# Altium schematic sheet 05_DC-DC_CONV.SchDoc(05_DC-DC_CONV)
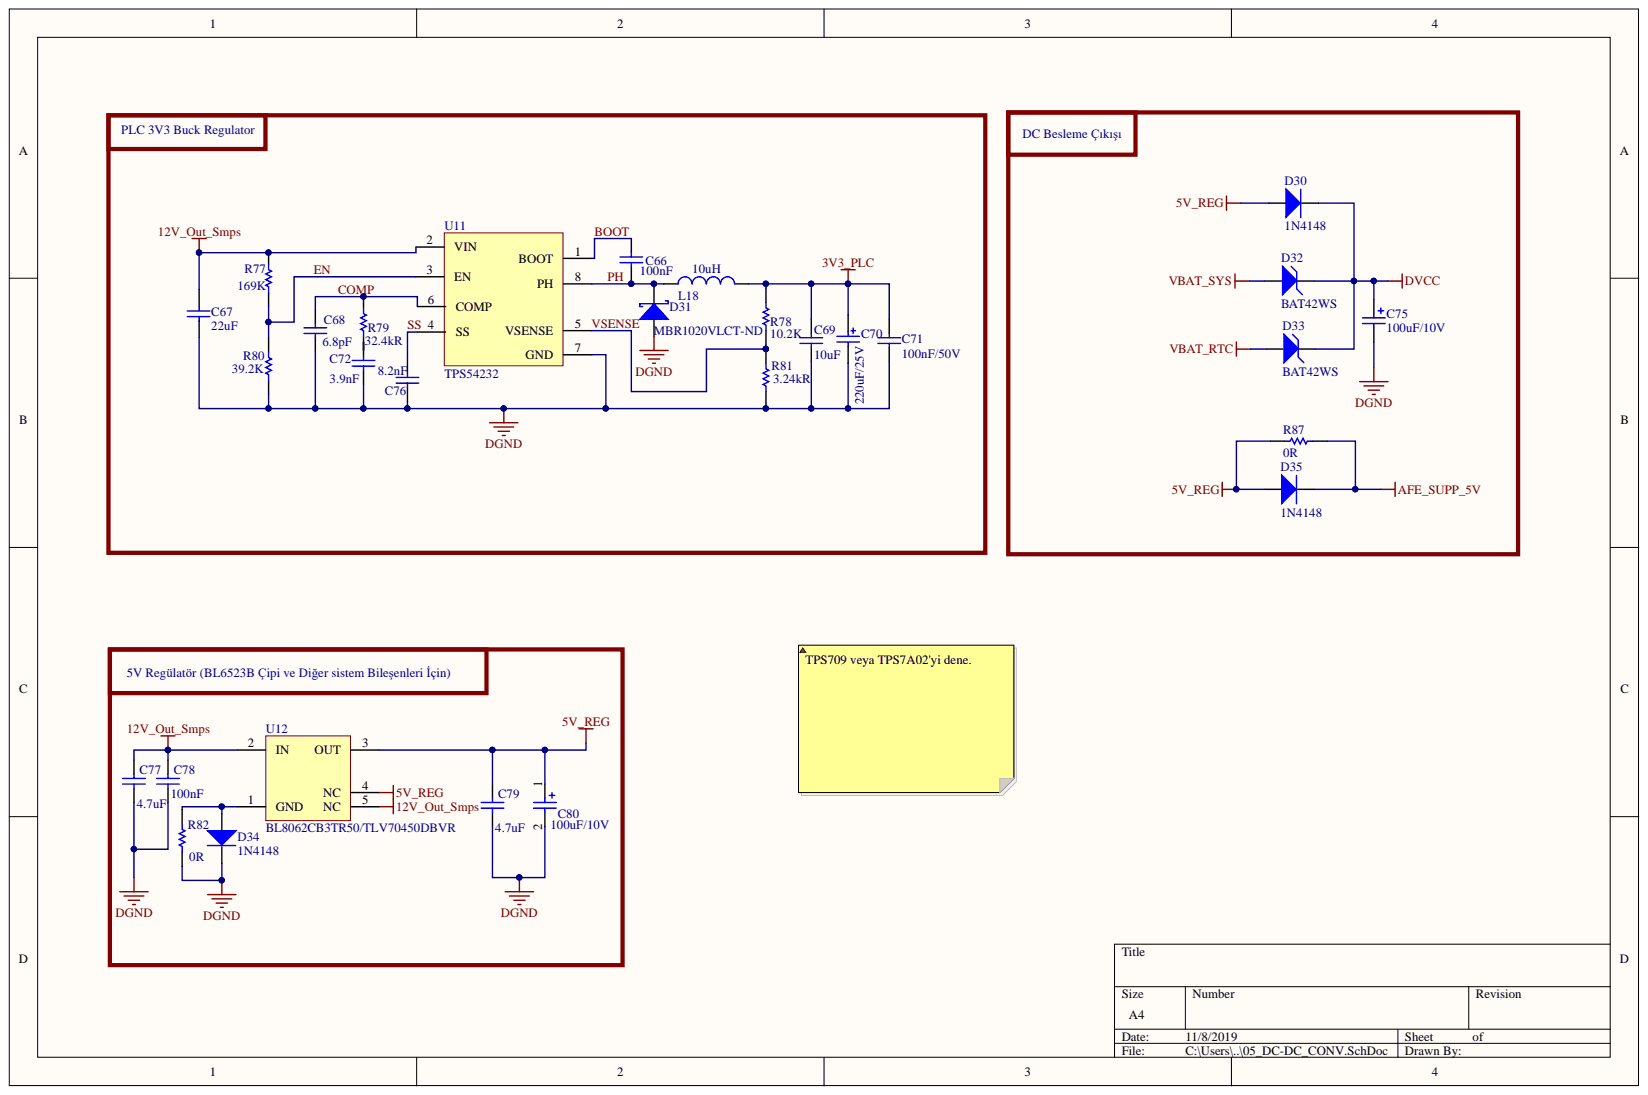
[diagram p0: 05_DC-DC_CONV.SchDoc]

## Parts
C66  Capacitance: 100nF  [CAP_0402]  (hidden comment: CAP_SMD)
C67  Capacitance: 22uF  [RES_1210_V1]  (hidden comment: GRM32ER61E226KE15L)
C68  Capacitance: 6.8pF  (hidden comment: CAP_SMD)
C69  Capacitance: 10uF  [CAP_0805_V1]  (hidden comment: CAP_SMD)
C70  220uF/25V  [CAP_ALU_ELC_RADIAL_D08.0_H12.5_P1-03.5_P2-05.0]
C71  100nF  [CAP_0603_V1]
C72  Capacitance: 3.9nF  [CAP_0805_V1]  (hidden comment: CAP_SMD)
C75  100uF/10V  [CAP_ALU_ELC_RADIAL_D06.3_H11.0_P1-02.5_P2-03.5]
C76  Capacitance: 8.2nF  [CAP_0402]  (hidden comment: CAP_SMD)
C77  Capacitance: 4.7uF  [CAP_0603_V1]  (hidden comment: CAP_SMD)
C78  Capacitance: 100nF  [CAP_0603_V1]  (hidden comment: CAP_SMD)
C79  Capacitance: 4.7uF  [CAP_0603_V1]  (hidden comment: CAP_SMD)
C80  100uF/10V  [CAP_ALU_ELC_RADIAL_D06.3_H11.0_P1-02.5_P2-03.5]
D30  1N4148  [SOD123 - SOD323]
D31  Supplier Part Number 1: MBR1020VLCT-ND  [SOD123 F- SOD323]  (hidden comment: DIODE SCHOTTKY)
D32  BAT42WS  [SOD123 - SOD323]
D33  BAT42WS  [SOD123 - SOD323]
D34  1N4148  [SOD123 - SOD323]
D35  1N4148  [SOD123 - SOD323]
L18  Inductance: 10uH  [IND_2SRN6045-100M]  (hidden comment: INDUCTOR_SMD)
R77  004_Value: 169K  [RES_0402_V1]  (hidden comment: RES_SMD)
R78  Resistance: 10.2K  [RES_0402_V1]  (hidden comment: RES_SMD)
R79  Resistance: 32.4kR  [RES_0402_V1]  (hidden comment: RES_SMD)
R80  004_Value: 39.2K  [RES_0402_V1]  (hidden comment: RES_SMD)
R81  Resistance: 3.24kR  [RES_0402_V1]  (hidden comment: RES_SMD)
R82  Resistance: 0R  [RES_0603_V1]  (hidden comment: RES_SMD)
R87  0R  [RES_0603_V1]
U11  TPS54232  [SOIC-8]
U12  BL8062CB3TR50 -- TLV70450DBVR  004_Value: BL8062CB3TR50/TLV70450DBVR  [SOT23-3_SOT23-5 (TI_TLV70450 & BELLING_BL8062CB3TR50)) - duplicate]

## Nets
3V3_PLC: C69.2 C70.1 C71.2 L18.2 R78.2
5V_REG: C79.2 C80.1 D30.1 D35.1 R87.1 U12.3(OUT) U12.4(NC)
12V_Out_Smps: C67.2 C77.2 C78.2 R77.2 U11.2(VIN) U12.2(IN) U12.5(NC)
AFE_SUPP_5V: D35.2 R87.2
DGND: C67.1 C68.1 C69.1 C70.2 C71.1 C72.1 C75.2 C76.1 C77.1 C78.1 C79.1 C80.2 D31.1 D34.2 R80.1 R81.1 R82.1 U11.7(GND)
DVCC: C75.1 D30.2 D32.2 D33.2
VBAT_RTC: D33.1
VBAT_SYS: D32.1
BOOT: C66.2 U11.1(BOOT)
COMP: C68.2 R79.2 U11.6(COMP)
EN: R77.1 R80.2 U11.3(EN)
PH: C66.1 D31.2 L18.1 U11.8(PH)
SS: C76.2 U11.4(SS)
VSENSE: R78.1 R81.2 U11.5(VSENSE)
NetC72_2: C72.2 R79.1
NetD34_1: D34.1 R82.2 U12.1(GND)

## Library data of the parts
C66 = CAP_SMD: 017_Manufacturer-1 PN 1: GRM155R71A104KA01D; 016_Manufacturer-1 1: Murata; Voltage Rating (DC): 10V; Tolerance: 10%; Dissipation Factor: 0.035%; Dielectric: X7R; Width: 0.02inch; Voltage Rating: 10V; Voltage: 10V; Thickness: 0.022inch; Termination: SMD/SMT; Supplier Part Number 1: 490-6321-1-ND; Supplier 1: Digi-Key; Stock 1: 6978211; RoHS: Yes; REACH SVHC: No SVHC; Radiation Hardening: No; Pricing 1: 1=0.10000, 10=0.03400, 100=0.01500, 500=0.01074, 1000=0.00844, 2500=0.00768, 5000=0.00706 (USD); Packaging: Tape and Reel; Package Quantity: 1; Number of Pins: 2; Mount: Surface Mount; Min Operating Temperature: -55°C; Max Operating Temperature: 125°C; Library Name: ElectricMeter.SchLib; Length: 1mm; Lead Free: Lead Free; Height: 0.5mm; Depth: 0.5mm; ComponentLink13URL: https://datasheet.ciiva.com/6271/partnumbering-e-01-6271135.pdf?src-supplier=Digi-Key; ComponentLink13Description: https://datasheet.ciiva.com/6271/partnumbering-e-01-6271135.pdf?src-supplier=Digi-Key; ComponentLink12URL: https://datasheet.ciiva.com/16199/c02e-16199258.pdf?src-supplier=Future+Electronics; ComponentLink12Description: https://datasheet.ciiva.com/16199/c02e-16199258.pdf?src-supplier=Future+Electronics; ComponentLink11URL: https://datasheet.ciiva.com/7937/1747441-7937613.pdf?src-supplier=Element14; ComponentLink11Description: https://datasheet.ciiva.com/7937/1747441-7937613.pdf?src-supplier=Element14; ComponentLink10URL: https://datasheet.ciiva.com/18184/getdatasheetpartid-130330-18184636.pdf?src-supplier=Verical; ComponentLink10Description: https://datasheet.ciiva.com/18184/getdatasheetpartid-130330-18184636.pdf?src-supplier=Verical; ComponentLink9URL: https://datasheet.ciiva.com/19475/1856345-19475961.pdf?src-supplier=Jameco; ComponentLink9Description: https://datasheet.ciiva.com/19475/1856345-19475961.pdf?src-supplier=Jameco; ComponentLink8URL: https://datasheet.ciiva.com/11789/0900766b80ef921d-11789061.pdf?src-supplier=RSComponents; ComponentLink8Description: https://datasheet.ciiva.com/11789/0900766b80ef921d-11789061.pdf?src-supplier=RSComponents; ComponentLink7URL: https://datasheet.ciiva.com/6271/product-6271137.pdf?src-supplier=Digi-Key; ComponentLink7Description: https://datasheet.ciiva.com/6271/product-6271137.pdf?src-supplier=Digi-Key; ComponentLink6URL: http://www.farnell.com/datasheets/2048783.pdf?src-supplier=Element14; ComponentLink6Description: http://www.farnell.com/datasheets/2048783.pdf?src-supplier=Element14; ComponentLink5URL: https://www.verical.com/server-webapp/html/getDatasheet?partID=130330&src-supplier=Verical; ComponentLink5Description: https://www.verical.com/server-webapp/html/getDatasheet?partID=130330&src-supplier=Verical; ComponentLink4URL: http://www.murata.com/products/catalog/pdf/c02e.pdf?src-supplier=Future+Electronics; ComponentLink4Description: http://www.murata.com/products/catalog/pdf/c02e.pdf?src-supplier=Future+Electronics; ComponentLink3URL: http://www.jameco.com/Jameco/Products/ProdDS/1856345.pdf?src-supplier=Jameco; ComponentLink3Description: http://www.jameco.com/Jameco/Products/ProdDS/1856345.pdf?src-supplier=Jameco; ComponentLink2URL: http://uk.rs-online.com/webdocs/1423/0900766b81423dae.pdf?src-supplier=RSComponents; ComponentLink2Description: http://uk.rs-online.com/webdocs/1423/0900766b81423dae.pdf?src-supplier=RSComponents; ComponentLink1URL: https://www.murata.com/~/media/webrenewal/support/library/catalog/products/capacitor/mlcc/c02e.ashx?la=en-us&src-supplier=Digi-Key; ComponentLink1Description: https://www.murata.com/~/media/webrenewal/support/library/catalog/products/capacitor/mlcc/c02e.ashx?la=en-us&src-supplier=Digi-Key; Component Kind: Standard; Case Code (Metric): 1005; Case Code (Imperial): 0402; Case/Package: 0402
C67 = GRM32ER61E226KE15L: CAP CER 22UF 25V X5R 1210; 016_Manufacturer-1: Murata; Tolerance: 10%; Parameter Name: Tape and Reel; Material: Ceramic; 006_SMT/THT: Surface Mount; Width: 2.5mm; Voltage Rating (DC): 25V; Voltage Rating: 25V; Voltage: 25V; Thickness: 0.106inch; Termination: SMD/SMT; Supplier Part Number 1: KKK15769; Supplier 1: Rutronik; Stock 1: 0; REACH SVHC: No SVHC; Radiation Hardening: No; Package Quantity: 1000; Number of Pins: 2; Min Operating Temperature: -55°C; Max Operating Temperature: 85°C; Library Name: ElectricMeter.SchLib; Length: 3.2mm; Lead Free: Lead Free; Height: 2.5mm; Dielectric: X5R; Depth: 2.5mm; ComponentLink8URL: http://components.arrow.com/part/dataview/43432935S8888103N2606?src-supplier=Arrow; ComponentLink8Description: http://components.arrow.com/part/dataview/43432935S8888103N2606?src-supplier=Arrow; ComponentLink7URL: https://www.distrelec.biz/Web/Downloads/_t/ds/murata_MLCC_eng_tds.pdf?mime=application%2Fpdf&src-supplier=Distrelec; ComponentLink7Description: https://www.distrelec.biz/Web/Downloads/_t/ds/murata_MLCC_eng_tds.pdf?mime=application%2Fpdf&src-supplier=Distrelec; ComponentLink6URL: http://www.farnell.com/datasheets/1951998.pdf?src-supplier=Element14; ComponentLink6Description: http://www.farnell.com/datasheets/1951998.pdf?src-supplier=Element14; ComponentLink5URL: http://www.farnell.com/datasheets/608985.pdf?src-supplier=Element14; ComponentLink5Description: http://www.farnell.com/datasheets/608985.pdf?src-supplier=Element14; ComponentLink4URL: http://search.murata.co.jp/Ceramy/image/img/w_hinm/L0006E.pdf?src-supplier=Future+Electronics; ComponentLink4Description: http://search.murata.co.jp/Ceramy/image/img/w_hinm/L0006E.pdf?src-supplier=Future+Electronics; ComponentLink3URL: http://psearch.en.murata.com/capacitor/product/GRM32ER61E226KE15%23.pdf?src-supplier=Avnet; ComponentLink3Description: http://psearch.en.murata.com/capacitor/product/GRM32ER61E226KE15%23.pdf?src-supplier=Avnet; ComponentLink2URL: https://www.murata.com/~/media/webrenewal/support/library/catalog/products/capacitor/mlcc/c02e.ashx?la=en-us&src-supplier=Digi-Key; ComponentLink2Description: https://www.murata.com/~/media/webrenewal/support/library/catalog/products/capacitor/mlcc/c02e.ashx?la=en-us&src-supplier=Digi-Key; ComponentLink1URL: http://uk.rs-online.com/webdocs/1423/0900766b81423c33.pdf?src-supplier=RSComponents; ComponentLink1Description: http://uk.rs-online.com/webdocs/1423/0900766b81423c33.pdf?src-supplier=RSComponents; Component Kind: Standard; Case Code (Metric): 3225; Case Code (Imperial): 1210; Case/Package: 1210; 017_Manufacturer-1 PN: GRM32ER61E226KE15L; 001_Description: CAP CER 22UF 25V X5R 1210
C68 = CAP_SMD: 017_Manufacturerr-1 PN 1: 06031U6R8BAT2A; 016_Manufacturer-1 1: Kyocera AVX; Voltage Rating (DC): 100V; Dielectric: C0G; Width: 0.03inch; Voltage Rating: 100V; Thickness: 0.036inch; Termination: SMD/SMT; Supplier Part Number 1: 06031U6R8BAT2A; Supplier 1: Avnet; Stock 1: 0; RoHS: Yes; Radiation Hardening: No; Pricing 1: 8000=0.04986, 16000=0.04821, 24000=0.04661, 40000=0.04516, 80000=0.04442 (USD); Packaging: Tape and Reel; Package Quantity: 4000; Mount: Surface Mount; Min Operating Temperature: -55°C; Max Operating Temperature: 125°C; Library Name: ElectricMeter.SchLib; Length: 1.52mm; Depth: 0.76mm; Composition: Ceramic; ComponentLink2URL: https://datasheet.ciiva.com/207/useries-207410.pdf?src-supplier=Digi-Key; ComponentLink2Description: https://datasheet.ciiva.com/207/useries-207410.pdf?src-supplier=Digi-Key; ComponentLink1URL: https://datasheet.ciiva.com/11550/useries-11550759.pdf?src-supplier=Avnet; ComponentLink1Description: https://datasheet.ciiva.com/11550/useries-11550759.pdf?src-supplier=Avnet; Component Kind: Standard; Case Code (Metric): 1608; Case Code (Imperial): 0603; Case/Package: 0603
C69 = CAP_SMD: 017_Manufacturer-1 PN 1: C0805C106K8PACTU; 016_Manufacturer-1 1: KEMET; Voltage Rating (DC): 10V; Tolerance: 10%; Dielectric Material: Ceramic; Dielectric: X5R; Width: 1.2mm; Voltage Rating: 10V; Voltage: 10V; Thickness: 0.055inch; Termination: SMD/SMT; Supplier Part Number 1: 399-4925-1-ND; Supplier 1: Digi-Key; Stock 1: 843727; RoHS: Yes; REACH SVHC: No SVHC; Radiation Hardening: No; Pricing 1: 1=0.16000, 10=0.11700, 100=0.05500, 500=0.03858, 1000=0.03194 (USD); Packaging: Tape and Reel; Package Quantity: 1; Number of Pins: 2; Mount: Surface Mount; Min Operating Temperature: -55°C; Max Operating Temperature: 85°C; Library Name: ElectricMeter.SchLib; Length: 2mm; Height: 1.25mm; Dissipation Factor: 10%; Depth: 1.25mm; Contact Plating: Tin,Matte; ComponentLink5URL: https://datasheet.ciiva.com/22704/1732709-22704859.pdf?src-supplier=Element14; ComponentLink5Description: https://datasheet.ciiva.com/22704/1732709-22704859.pdf?src-supplier=Element14; ComponentLink4URL: https://datasheet.ciiva.com/7842/40889-7842552.pdf?src-supplier=Element14; ComponentLink4Description: https://datasheet.ciiva.com/7842/40889-7842552.pdf?src-supplier=Element14; ComponentLink3URL: https://datasheet.ciiva.com/7842/1732709-7842198.pdf?src-supplier=Element14; ComponentLink3Description: https://datasheet.ciiva.com/7842/1732709-7842198.pdf?src-supplier=Element14; ComponentLink2URL: http://www.farnell.com/datasheets/40889.pdf?src-supplier=Element14; ComponentLink2Description: http://www.farnell.com/datasheets/40889.pdf?src-supplier=Element14; ComponentLink1URL: http://www.farnell.com/datasheets/2739827.pdf?src-supplier=Element14; ComponentLink1Description: http://www.farnell.com/datasheets/2739827.pdf?src-supplier=Element14; Component Kind: Standard; Case Code (Metric): 2012; Case Code (Imperial): 0805; Case/Package: 0805
C70 = RS11E221MF110B35CE0: Chang, 220uF, 25V, 8x12,5mm, P3.5mm; Tolerance: 1%; Temperature Coefficient: 100ppm/°C; Resistance: 11R; Power Rating: 100mW; Termination: Solder; Supplier Part Number 1: 804-8697; Supplier 1: RSComponents; Stock 1: 0; Mount: Surface Mount; Min Operating Temperature: -55°C; Max Operating Temperature: 155°C; Library Name: ElectricMeter.SchLib; Length: 1.6mm; Lead Free: Lead Free; Height: 0.45mm; Depth: 0.8mm; Component Kind: Standard; Case/Package: 0603; 017_Manufacturer-1 PN: RS11E221MF110B35CE0; 016_Manufacturer-1: Chang; 004_Value: 220uF/25V
C71 = 100nF/50V: MLCC, 100nF, 50V, X7R, 0603, %10; 017_Manufacturer-1 PN: CL10B104KB8NNNC; 016_Manufacturer-1: Samsung; 008_Package: C0603; 007_Status: MP; 006_SMT/THT: SMT; 005_Process: SMD_Mounter; 001_Designation: Capacitor; Library Name: BM.10.LC02.SCHLIB; Component Kind: Standard; 004_Value: 100nF/50V
C72 = CAP_SMD: 017_Manufacturer-1 PN 1: CGA4C2C0G1H392J060AA; 016_Manufacturer-1 1: TDK; Voltage Rating (DC): 50V; Tolerance: 5%; Dielectric: C0G; Width: 0.049inch; Weight: 0.000194oz; Voltage Rating: 50V; Thickness: 0.028inch; Termination: SMD/SMT; Supplier Part Number 1: 445-6942-1-ND; Supplier 1: Digi-Key; Stock 1: 3935; RoHS: Yes; Ratings: AEC-Q200; Pricing 1: 1=0.25000, 10=0.17300, 100=0.08740, 500=0.05980, 1000=0.05290 (USD); Packaging: Tape and Reel; Package Quantity: 1; Mount: Surface Mount; Min Operating Temperature: -55°C; Max Operating Temperature: 125°C; Library Name: ElectricMeter.SchLib; Length: 2mm; Height: 0.6mm; Depth: 1.25mm; Composition: Ceramic; ComponentLink5URL: https://datasheet.ciiva.com/24219/mlcc-automotive-general-en-24219624.pdf?src-supplier=Mouser; ComponentLink5Description: https://datasheet.ciiva.com/24219/mlcc-automotive-general-en-24219624.pdf?src-supplier=Mouser; ComponentLink4URL: http://product.tdk.com/en/catalog/datasheets/mlcc_automotive_general_en.pdf?src-supplier=Avnet; ComponentLink4Description: http://product.tdk.com/en/catalog/datasheets/mlcc_automotive_general_en.pdf?src-supplier=Avnet; ComponentLink3URL: http://www.mouser.com/ds/2/400/lcc_automotive_general_en-843974.pdf?src-supplier=Mouser; ComponentLink3Description: http://www.mouser.com/ds/2/400/lcc_automotive_general_en-843974.pdf?src-supplier=Mouser; ComponentLink2URL: https://product.tdk.com/info/en/documents/chara_sheet/CGA4C2C0G1H182J060AA.pdf?src-supplier=Digi-Key; ComponentLink2Description: https://product.tdk.com/info/en/documents/chara_sheet/CGA4C2C0G1H182J060AA.pdf?src-supplier=Digi-Key; ComponentLink1URL: https://product.tdk.com/info/en/catalog/datasheets/mlcc_automotive_general_en.pdf?src-supplier=Digi-Key; ComponentLink1Description: https://product.tdk.com/info/en/catalog/datasheets/mlcc_automotive_general_en.pdf?src-supplier=Digi-Key; Component Kind: Standard; Case Code (Metric): 2012; Case Code (Imperial): 0805; Case/Package: 0805
C75 = GF1A101KC110B25CE: Capacitor, Electrolytic, 100uF, 10V, 5x11mm, P2.5mm, 2000H, 105°C; 016_Manufacturer-1: Chang; Value: 100uF/10V; Library Name: ElectricMeter.SchLib; Component Kind: Standard; 017_Manufacturer-1 PN: GF1A101KC110B25CE; 001_Designation: Capacitor
C76 = CAP_SMD: 017_Manufacturer-1 PN: GRM033R71A822KA01D; 016_Manufacturer-1: Murata; Voltage Rating (DC): 10V; Tolerance: 10%; Parameter Name: Tape and Reel; Dielectric: X7R; 006_SMT/THT: Surface Mount; 001_Description: Multilayer Ceramic Capacitors MLCC - SMD/SMT 0.0082uF 10volts 10%; Width: 0.012inch; Voltage Rating: 10V; Thickness: 0.013inch; Termination: SMD/SMT; Supplier Part Number 1: GRM033R71A822KA01D; Supplier 1: Perfect Parts; Stock 1: 0; Radiation Hardening: No; Package Quantity: 15000; Min Operating Temperature: -55°C; Max Operating Temperature: 125°C; Library Name: ElectricMeter.SchLib; Length: 0.6mm; Height: 0.3mm; Depth: 0.3mm; ComponentLink1URL: http://search.murata.co.jp/Ceramy/image/img/A01X/partnumbering_e_01.pdf?src-supplier=Digi-Key; ComponentLink1Description: http://search.murata.co.jp/Ceramy/image/img/A01X/partnumbering_e_01.pdf?src-supplier=Digi-Key; Component Kind: Standard; Case Code (Metric): 0603; Case Code (Imperial): 0201; Case/Package: 0201
C77 C79 = CAP_SMD: 017_Manufacturer-1 PN: CL10A475KO8NNNC; 016_Manufacturer-1: Samsung; Voltage Rating: 16V; Tolerance: 10%; Parameter Name: Tape and Reel; Material: Ceramic; Dielectric: X5R; 006_SMT/THT: Surface Mount; 001_Description: Cap Ceramic 4.7uF 16V X5R 10% SMD 0603 85C Paper T/R; Width: 0.031inch; Voltage: 16V; Thickness: 0.035inch; Supplier Part Number 1: 766-1009P; Supplier 1: RSComponents; Stock 1: 0; Radiation Hardening: No; Package Quantity: 1; Min Operating Temperature: -55°C; Max Operating Temperature: 85°C; Library Name: ElectricMeter.SchLib; Length: 1.6mm; Height: 0.8mm; Depth: 0.8mm; Contact Plating: Tin; ComponentLink3URL: https://produktinfo.conrad.com/datenblaetter/450000-474999/457663-da-01-en-VS_KONDENSATOR_0603.pdf?src-supplier=Conrad; ComponentLink3Description: https://produktinfo.conrad.com/datenblaetter/450000-474999/457663-da-01-en-VS_KONDENSATOR_0603.pdf?src-supplier=Conrad; ComponentLink2URL: https://www.verical.com/server-webapp/html/getDatasheet?partID=358619&src-supplier=Verical; ComponentLink2Description: https://www.verical.com/server-webapp/html/getDatasheet?partID=358619&src-supplier=Verical; ComponentLink1URL: http://www.samsungsem.com/kr/support/product-search/mlcc/CL10A475KO8NNNC.jsp?src-supplier=Digi-Key; ComponentLink1Description: http://www.samsungsem.com/kr/support/product-search/mlcc/CL10A475KO8NNNC.jsp?src-supplier=Digi-Key; Component Kind: Standard; Case Code (Metric): 1608; Case Code (Imperial): 0603; Case/Package: 0603
C78 = CAP_SMD: MLCC; 100nF; 50V; X7R; ±10%; SMD; 0603; -55÷125°C; 017_Manufacturer-1 PN: CL10B104KB8NNNC; 016_Manufacturer-1: Samsung; Parameter Name: Tape and Reel; Material: Ceramic; 006_SMT/THT: Surface Mount; 001_Description: Capacitor: ceramic; MLCC; 100nF; 50V; X7R; ±10%; SMD; 0603; -55÷125°C; Width: 0.031inch; Voltage Rating (DC): 50V; Voltage Rating: 50V; Voltage: 50V; Tolerance: 10%; Thickness: 0.035inch; Supplier Part Number 1: 766-5443; Supplier 1: RSComponents; Stock 1: 6876; Radiation Hardening: No; Pricing 1: 1=29.65, 3=27.68, 5=25.96, 10=24.42, 25=23.06 (EUR); Package Quantity: 1; Min Operating Temperature: -55°C; Max Operating Temperature: 125°C; Library Name: ElectricMeter.SchLib; Length: 1.6mm; Lead Free: Lead Free; Height: 0.8mm; Dielectric: X7R; Depth: 0.8mm; Contact Plating: Tin; ComponentLink3URL: https://produktinfo.conrad.com/datenblaetter/450000-474999/456651-da-01-en-VS_KONDENSATOR_0605.pdf?src-supplier=Conrad; ComponentLink3Description: https://produktinfo.conrad.com/datenblaetter/450000-474999/456651-da-01-en-VS_KONDENSATOR_0605.pdf?src-supplier=Conrad; ComponentLink2URL: https://www.verical.com/server-webapp/html/getDatasheet?partID=89097&src-supplier=Verical; ComponentLink2Description: https://www.verical.com/server-webapp/html/getDatasheet?partID=89097&src-supplier=Verical; ComponentLink1URL: http://www.samsungsem.com/kr/support/product-search/mlcc/CL10B104KB8NNNC.jsp?src-supplier=Digi-Key; ComponentLink1Description: http://www.samsungsem.com/kr/support/product-search/mlcc/CL10B104KB8NNNC.jsp?src-supplier=Digi-Key; Component Kind: Standard; Case Code (Metric): 1608; Case Code (Imperial): 0603; Case/Package: 0603
C80 = 100uF/10V: Capacitor, Electrolytic, 100uF, 10V, 5x11mm, P2.5mm, 2000H, 105°C; 017_Manufacturer-1 PN: GF1A101KC110B25CE; 016_Manufacturer-1: Chang; Value: 100uF/10V; Library Name: ElectricMeter.SchLib; Component Kind: Standard; 001_Designation: Capacitor
D30 D34 D35 = 1N4148W-7-F: DIODE GEN PURP 100V 300MA SOD123; 016_Manufacturer-1: Diodes; Voltage Rating (DC): 75V; Reverse Recovery Time: 4ns; Power Rating: 400mW; Polarity: Standard; Peak Reverse Current: 1uA; Peak Non-Repetitive Surge Current: 2A; Output Current: 150mA; Forward Voltage: 1.25V; Element Configuration: Single; Current: 15A; Capacitance: 2pF; Width: 1.7mm; Weight: 0.000353oz; Voltage: 100V; Supplier Part Number 1: 1N4148W-FDITR-ND; Supplier 1: Digi-Key; Stock 1: 1371000; Recovery Time: 4ns; REACH SVHC: No SVHC; Radiation Hardening: No; Pricing 1: 3000=0.03086, 6000=0.02783, 15000=0.0242, 30000=0.02178, 75000=0.01936, 150000=0.01609 (USD); Power Dissipation: 400mW; Packaging: Tape and Reel; Package Quantity: 3000; Number of Pins: 2; Mount: Surface Mount; Min Operating Temperature: -65°C; Max Surge Current: 2A; Max Reverse Voltage (DC): 100V; Max Repetitive Reverse Voltage (Vrrm): 100V; Max Operating Temperature: 150°C; Max Forward Surge Current (Ifsm): 2A; Library Name: ElectricMeter.SchLib; Length: 2.85mm; Lead Free: Lead Free; Height: 1.25mm; Forward Current: 150mA; Current Rating: 150mA; Contact Plating: Matte,Tin; ComponentLink3URL: http://www.mouser.com/ds/2/115/ds30086-60872.pdf; ComponentLink3Description: http://www.mouser.com/ds/2/115/ds30086-60872.pdf; ComponentLink2URL: http://www.farnell.com/datasheets/1915529.pdf; ComponentLink2Description: http://www.farnell.com/datasheets/1915529.pdf; ComponentLink1URL: https://www.diodes.com/assets/Datasheets/ds30086.pdf; ComponentLink1Description: https://www.diodes.com/assets/Datasheets/ds30086.pdf; Component Kind: Standard; Average Rectified Current: 300mA; 017_Manufacturer-1 PN: 1N4148W-7-F
D31 = DIODE_SCHOTTKY: DIODE SCHOTTKY 30V 200MA SOD323; 017_Manufacturer-1 PN 1: MBR1020VL; 016_Manufacturer-1 1: ON Semiconductor / Fairchild; Reverse Recovery Time: 12.4ns; Max Reverse Voltage (DC): 20V; Max Forward Surge Current (Ifsm): 45A; Element Configuration: Single; Average Rectified Current: 1A; Weight: 0.0276g; Supplier 1: Digi-Key; Stock 1: 11018; RoHS: Yes; Pricing 1: 1=0.26000, 10=0.21000, 100=0.11150, 500=0.07338, 1000=0.04990 (USD); Packaging: Tape and Reel; Mount: Surface Mount; Max Repetitive Reverse Voltage (Vrrm): 20V; Library Name: ElectricMeter.SchLib; ComponentLink7URL: http://www.farnell.com/datasheets/2552643.pdf?src-supplier=Newark; ComponentLink7Description: http://www.farnell.com/datasheets/2552643.pdf?src-supplier=Newark; ComponentLink6URL: https://www.fairchildsemi.com/datasheets/MB/MBR1020VL.pdf?src-supplier=Digi-Key; ComponentLink6Description: https://www.fairchildsemi.com/datasheets/MB/MBR1020VL.pdf?src-supplier=Digi-Key; ComponentLink5URL: https://www.diodes.com/assets/Datasheets/ds30100.pdf?src-supplier=Digi-Key; ComponentLink5Description: https://www.diodes.com/assets/Datasheets/ds30100.pdf?src-supplier=Digi-Key; ComponentLink4URL: http://www.farnell.com/datasheets/1671074.pdf?src-supplier=Element14; ComponentLink4Description: http://www.farnell.com/datasheets/1671074.pdf?src-supplier=Element14; ComponentLink3URL: http://www.farnell.com/datasheets/2552643.pdf?src-supplier=Farnell; ComponentLink3Description: http://www.farnell.com/datasheets/2552643.pdf?src-supplier=Farnell; ComponentLink2URL: https://www.fairchildsemi.com/package-drawings/DO/DO214AA.pdf?src-supplier=Digi-Key; ComponentLink2Description: https://www.fairchildsemi.com/package-drawings/DO/DO214AA.pdf?src-supplier=Digi-Key; ComponentLink1URL: http://www.farnell.com/datasheets/1766344.pdf?src-supplier=Element14; ComponentLink1Description: http://www.farnell.com/datasheets/1766344.pdf?src-supplier=Element14; Component Kind: Standard
D32 D33 = BAT42WS-7-F: DIODE SCHOTTKY 30V 200MA SOD323; 016_Manufacturer-1: Diodes; Reverse Recovery Time: 5ns; Power Rating: 200mW; Polarity: Standard; Peak Reverse Current: 500nA; Peak Non-Repetitive Surge Current: 4A; Parameter Name: Tape and Reel; Forward Voltage: 1V; Forward Current: 100mA; Element Configuration: Single; Capacitance: 10pF; Width: 1.4mm; Weight: 0.000173oz; Voltage Rating (DC): 30V; Termination: SMD/SMT; Supplier Part Number 1: BAT42WS-7-F; Supplier 1: Verical; Stock 1: 875; REACH SVHC: No SVHC; Radiation Hardening: No; Pricing 1: 143=0.1121, 250=0.1009, 500=0.0908, 1000=0.0855 (USD); Power Dissipation: 200mW; Package Quantity: 3000; Output Current: 200mA; Number of Pins: 2; Mount: Surface Mount; Min Operating Temperature: -55°C; Max Reverse Voltage (DC): 30V; Max Repetitive Reverse Voltage (Vrrm): 30V; Max Power Dissipation: 200mW; Max Operating Temperature: 125°C; Max Forward Surge Current (Ifsm): 4A; Library Name: ElectricMeter.SchLib; Length: 1.8mm; Lead Free: Lead Free; Height: 1mm; Current Rating: 200mA; Contact Plating: Matte,Tin; ComponentLink5URL: https://www.diodes.com/assets/Datasheets/ds30100.pdf?src-supplier=Digi-Key; ComponentLink5Description: https://www.diodes.com/assets/Datasheets/ds30100.pdf?src-supplier=Digi-Key; ComponentLink4URL: http://www.farnell.com/datasheets/1671074.pdf?src-supplier=Element14; ComponentLink4Description: http://www.farnell.com/datasheets/1671074.pdf?src-supplier=Element14; ComponentLink3URL: http://www.diodes.com//datasheets/ds30100.pdf?src-supplier=Avnet+Europe; ComponentLink3Description: http://www.diodes.com//datasheets/ds30100.pdf?src-supplier=Avnet+Europe; ComponentLink2URL: http://www.diodes.com//datasheets/ds30100.pdf?src-supplier=Avnet; ComponentLink2Description: http://www.diodes.com//datasheets/ds30100.pdf?src-supplier=Avnet; ComponentLink1URL: http://www.mouser.com/ds/2/115/ds30100-83070.pdf?src-supplier=Mouser; ComponentLink1Description: http://www.mouser.com/ds/2/115/ds30100-83070.pdf?src-supplier=Mouser; Component Kind: Standard; Average Rectified Current: 200mA; 017_Manufacturer-1 PN: BAT42WS-7-F
L18 = INDUCTOR_SMD: 017_Manufacturer-1 PN 1: SRN6045-100M; 016_Manufacturer-1 1: Bourns; Tolerance: 20%; Test Frequency: 100kHz; Shielding: Shielded; Self Resonant Frequency: 15MHz; Saturation Current: 3A; RMS Current (Irms): 2.5A; Material: Steel; DC Resistance (DCR): 58.6mR; Core Material: Ferrite; Width: 6mm; Weight: 0.027725oz; Termination: SMD/SMT; Supplier Part Number 1: SRN6045-100MCT-ND; Supplier 1: Digi-Key; Stock 1: 13686; RoHS: Yes; REACH SVHC: No SVHC; Pricing 1: 1=0.55000, 10=0.48300, 100=0.34500, 500=0.28980 (USD); Packaging: Tape and Reel; Package Quantity: 1; Number of Pins: 2; Mount: Surface Mount; Min Operating Temperature: -40°C; Military Standard: Not; Max Operating Temperature: 125°C; Max DC Current: 2.5A; Library Name: ElectricMeter.SchLib; Length: 6mm; Inductance Tolerance: 20%; Height - Seated (Max): 0.177inch; Height: 4.5mm; Depth: 6.2mm; DC Current: 2.5A; Current Rating: 2.5A; Composition: Wirewound; ComponentLink12URL: https://datasheet.ciiva.com/48691/001056442-da-01-en-mag-abg-leist-ind-srn6045-100m-10uh-smd-48691818.pdf?src-supplier=Conrad; ComponentLink12Description: https://datasheet.ciiva.com/48691/001056442-da-01-en-mag-abg-leist-ind-srn6045-100m-10uh-smd-48691818.pdf?src-supplier=Conrad; ComponentLink11URL: https://datasheet.ciiva.com/7849/1875285-7849518.pdf?src-supplier=Element14; ComponentLink11Description: https://datasheet.ciiva.com/7849/1875285-7849518.pdf?src-supplier=Element14; ComponentLink10URL: https://datasheet.ciiva.com/28561/1875285-28561101.pdf?src-supplier=Element14; ComponentLink10Description: https://datasheet.ciiva.com/28561/1875285-28561101.pdf?src-supplier=Element14; ComponentLink9URL: https://datasheet.ciiva.com/17284/srn6045-17284746.pdf?src-supplier=Avnet+Europe; ComponentLink9Description: https://datasheet.ciiva.com/17284/srn6045-17284746.pdf?src-supplier=Avnet+Europe; ComponentLink8URL: https://datasheet.ciiva.com/17113/70231653-17113272.pdf?src-supplier=Allied+Electronics; ComponentLink8Description: https://datasheet.ciiva.com/17113/70231653-17113272.pdf?src-supplier=Allied+Electronics; ComponentLink7URL: https://datasheet.ciiva.com/6689/srn6045-6689374.pdf?src-supplier=Digi-Key; ComponentLink7Description: https://datasheet.ciiva.com/6689/srn6045-6689374.pdf?src-supplier=Digi-Key; ComponentLink6URL: https://datasheet.ciiva.com/6689/srn6045-6689372.pdf?src-supplier=Digi-Key; ComponentLink6Description: https://datasheet.ciiva.com/6689/srn6045-6689372.pdf?src-supplier=Digi-Key; ComponentLink5URL: https://datasheet.ciiva.com/6689/srn6045-6689370.pdf?src-supplier=Digi-Key; ComponentLink5Description: https://datasheet.ciiva.com/6689/srn6045-6689370.pdf?src-supplier=Digi-Key; ComponentLink4URL: https://datasheet.ciiva.com/8512/srn6045-8512981.pdf?src-supplier=Avnet; ComponentLink4Description: https://datasheet.ciiva.com/8512/srn6045-8512981.pdf?src-supplier=Avnet; ComponentLink3URL: http://www.bourns.com/pdfs/SRR6028.pdf?src-supplier=Avnet; ComponentLink3Description: http://www.bourns.com/pdfs/SRR6028.pdf?src-supplier=Avnet; ComponentLink2URL: https://www.bourns.com/docs/Product-Datasheets/SRR6028.pdf?src-supplier=Digi-Key; ComponentLink2Description: https://www.bourns.com/docs/Product-Datasheets/SRR6028.pdf?src-supplier=Digi-Key; ComponentLink1URL: https://datasheet.ciiva.com/11802/0900766b80fe1f3e-11802575.pdf?src-supplier=RSComponents; ComponentLink1Description: https://datasheet.ciiva.com/11802/0900766b80fe1f3e-11802575.pdf?src-supplier=RSComponents; Component Kind: Standard; Case/Package: Nonstandard
R77 = RES_SMD: Manufacturer Part Number 1: CRCW0402169KFKED; Manufacturer 1: Vishay; Voltage Rating (DC): 50V; Tolerance: 1%; Temperature Coefficient: 100ppm/°C; Resistance: 169kR; Power Rating: 63mW; Width: 0.02inch; Weight: 2.3E-05oz; Voltage Rating: 50V; Termination: SMD/SMT; Supplier Part Number 1: 541-169KLCT-ND; Supplier 1: Digi-Key; Stock 1: 26802; RoHS: Yes; REACH SVHC: Unknown; Radiation Hardening: No; Pricing 1: 1=0.10000, 10=0.03800, 100=0.01540, 1000=0.00690, 2500=0.00599, 5000=0.00495 (USD); Packaging: Tape and Reel; Package Quantity: 10; Number of Terminations: 2; Number of Pins: 2; Mount: Surface Mount; Min Operating Temperature: -55°C; Military Standard: Not; Max Power Dissipation: 63mW; Max Operating Temperature: 155°C; Library Name: ElectricMeter.SchLib; Length: 1mm; Lead Free: Lead Free; Height: 0.35mm; Features: Automotive AEC-Q200; Depth: 0.5mm; Contact Plating: Tin; Composition: Thick Film; ComponentLink18URL: https://datasheet.ciiva.com/22872/70201119-22872173.pdf?src-supplier=Allied+Electronics; ComponentLink18Description: https://datasheet.ciiva.com/22872/70201119-22872173.pdf?src-supplier=Allied+Electronics; ComponentLink17URL: https://datasheet.ciiva.com/22675/1825492-22675541.pdf?src-supplier=Element14; ComponentLink17Description: https://datasheet.ciiva.com/22675/1825492-22675541.pdf?src-supplier=Element14; ComponentLink16URL: https://datasheet.ciiva.com/16880/dcrcwe3-16880917.pdf?src-supplier=Avnet+Europe; ComponentLink16Description: https://datasheet.ciiva.com/16880/dcrcwe3-16880917.pdf?src-supplier=Avnet+Europe; ComponentLink15URL: https://datasheet.ciiva.com/2463/dcrcwe3-2463285.pdf?src-supplier=Digi-Key; ComponentLink15Description: https://datasheet.ciiva.com/2463/dcrcwe3-2463285.pdf?src-supplier=Digi-Key; ComponentLink14URL: https://datasheet.ciiva.com/16769/70201119-16769132.pdf?src-supplier=Allied+Electronics; ComponentLink14Description: https://datasheet.ciiva.com/16769/70201119-16769132.pdf?src-supplier=Allied+Electronics; ComponentLink13URL: https://datasheet.ciiva.com/3256/dcrcwe3-3256092.pdf?src-supplier=Avnet; ComponentLink13Description: https://datasheet.ciiva.com/3256/dcrcwe3-3256092.pdf?src-supplier=Avnet; ComponentLink12URL: https://datasheet.ciiva.com/5542/dcrcwe3-109170-5542779.pdf?src-supplier=Mouser; ComponentLink12Description: https://datasheet.ciiva.com/5542/dcrcwe3-109170-5542779.pdf?src-supplier=Mouser; ComponentLink11URL: https://datasheet.ciiva.com/3256/dcrcwe3-3256091.pdf?src-supplier=Avnet; ComponentLink11Description: https://datasheet.ciiva.com/3256/dcrcwe3-3256091.pdf?src-supplier=Avnet; ComponentLink10URL: https://datasheet.ciiva.com/16207/dcrcwe3-16207496.pdf?src-supplier=Future+Electronics; ComponentLink10Description: https://datasheet.ciiva.com/16207/dcrcwe3-16207496.pdf?src-supplier=Future+Electronics; ComponentLink9URL: https://datasheet.ciiva.com/2463/dcrcwe3-2463284.pdf?src-supplier=Digi-Key; ComponentLink9Description: https://datasheet.ciiva.com/2463/dcrcwe3-2463284.pdf?src-supplier=Digi-Key; ComponentLink8URL: https://datasheet.ciiva.com/2463/dcrcwe3-2463283.pdf?src-supplier=Digi-Key; ComponentLink8Description: https://datasheet.ciiva.com/2463/dcrcwe3-2463283.pdf?src-supplier=Digi-Key; ComponentLink7URL: https://datasheet.ciiva.com/11810/0900766b80d98824-11810174.pdf?src-supplier=RSComponents; ComponentLink7Description: https://datasheet.ciiva.com/11810/0900766b80d98824-11810174.pdf?src-supplier=RSComponents; ComponentLink6URL: http://components.arrow.com/part/dataview/0323_00200772?src-supplier=Arrow+Europe; ComponentLink6Description: http://components.arrow.com/part/dataview/0323_00200772?src-supplier=Arrow+Europe; ComponentLink5URL: http://www.mouser.com/ds/2/447/RC0603-272842.pdf?src-supplier=Mouser; ComponentLink5Description: http://www.mouser.com/ds/2/447/RC0603-272842.pdf?src-supplier=Mouser; ComponentLink4URL: http://www.yageo.com/documents/recent/PYu-RC_Group_51_RoHS_L_10.pdf?src-supplier=Digi-Key; ComponentLink4Description: http://www.yageo.com/documents/recent/PYu-RC_Group_51_RoHS_L_10.pdf?src-supplier=Digi-Key; ComponentLink3URL: http://www.yageo.com/documents/recent/PYu-RC_Group_51_RoHS_L_9.pdf?src-supplier=Digi-Key; ComponentLink3Description: http://www.yageo.com/documents/recent/PYu-RC_Group_51_RoHS_L_9.pdf?src-supplier=Digi-Key; ComponentLink2URL: https://www.verical.com/server-webapp/html/getDatasheet?partID=326480&src-supplier=Verical; ComponentLink2Description: https://www.verical.com/server-webapp/html/getDatasheet?partID=326480&src-supplier=Verical; ComponentLink1URL: http://www.yageo.com/documents/recent/PYu-RC0603_51_RoHS_L_v5.pdf?src-supplier=Future+Electronics; ComponentLink1Description: http://www.yageo.com/documents/recent/PYu-RC0603_51_RoHS_L_v5.pdf?src-supplier=Future+Electronics; Component Kind: Standard; Case Code (Metric): 1005; Case Code (Imperial): 0402; Case/Package: 0402
R78 = RES_SMD: 017_Manufacturer-1 PN 1: CRCW040210K2FKED; 016_Manufacturer-1 1: Vishay; Voltage Rating: 50V; Tolerance: 1%; Temperature Coefficient: 100ppm/°C; Power Rating: 63mW; Width: 0.5mm; Weight: 2.3E-05oz; Termination: SMD/SMT; Supplier Part Number 1: CRCW040210K2FKED; Supplier 1: Avnet; Stock 1: 20000; RoHS: Yes; REACH SVHC: Unknown; Radiation Hardening: No; Pricing 1: 20000=0.01136, 40000=0.01105, 60000=0.01075, 100000=0.01047, 200000=0.01020 (USD); Packaging: Tape and Reel; Package Quantity: 10; Number of Terminations: 2; Number of Pins: 2; Mount: Surface Mount; Min Operating Temperature: -55°C; Military Standard: Not; Max Power Dissipation: 63mW; Max Operating Temperature: 155°C; Library Name: ElectricMeter.SchLib; Length: 1mm; Height: 0.35mm; Features: Automotive AEC-Q200; Depth: 0.5mm; Contact Plating: Tin; Composition: Thick Film; ComponentLink16URL: https://datasheet.ciiva.com/22702/1697337-22702863.pdf?src-supplier=Element14; ComponentLink16Description: https://datasheet.ciiva.com/22702/1697337-22702863.pdf?src-supplier=Element14; ComponentLink15URL: https://datasheet.ciiva.com/16394/dcrcwe3-16394143.pdf?src-supplier=Future+Electronics; ComponentLink15Description: https://datasheet.ciiva.com/16394/dcrcwe3-16394143.pdf?src-supplier=Future+Electronics; ComponentLink14URL: https://datasheet.ciiva.com/16881/dcrcwe3-16881433.pdf?src-supplier=Avnet+Europe; ComponentLink14Description: https://datasheet.ciiva.com/16881/dcrcwe3-16881433.pdf?src-supplier=Avnet+Europe; ComponentLink13URL: https://datasheet.ciiva.com/7663/1697337-7663814.pdf?src-supplier=Element14; ComponentLink13Description: https://datasheet.ciiva.com/7663/1697337-7663814.pdf?src-supplier=Element14; ComponentLink12URL: https://datasheet.ciiva.com/7369/1635184-7369334.pdf?src-supplier=Newark; ComponentLink12Description: https://datasheet.ciiva.com/7369/1635184-7369334.pdf?src-supplier=Newark; ComponentLink11URL: https://datasheet.ciiva.com/3255/dcrcwe3-3255966.pdf?src-supplier=Avnet; ComponentLink11Description: https://datasheet.ciiva.com/3255/dcrcwe3-3255966.pdf?src-supplier=Avnet; ComponentLink10URL: https://datasheet.ciiva.com/16772/70201119-16772093.pdf?src-supplier=Allied+Electronics; ComponentLink10Description: https://datasheet.ciiva.com/16772/70201119-16772093.pdf?src-supplier=Allied+Electronics; ComponentLink9URL: https://datasheet.ciiva.com/22870/70201119-22870522.pdf?src-supplier=Allied+Electronics; ComponentLink9Description: https://datasheet.ciiva.com/22870/70201119-22870522.pdf?src-supplier=Allied+Electronics; ComponentLink8URL: https://datasheet.ciiva.com/7743/125364-7743418.pdf?src-supplier=Element14; ComponentLink8Description: https://datasheet.ciiva.com/7743/125364-7743418.pdf?src-supplier=Element14; ComponentLink7URL: https://datasheet.ciiva.com/2525/dcrcwe3-2525640.pdf?src-supplier=Digi-Key; ComponentLink7Description: https://datasheet.ciiva.com/2525/dcrcwe3-2525640.pdf?src-supplier=Digi-Key; ComponentLink6URL: https://datasheet.ciiva.com/7663/1697337-7663815.pdf?src-supplier=Element14; ComponentLink6Description: https://datasheet.ciiva.com/7663/1697337-7663815.pdf?src-supplier=Element14; ComponentLink5URL: https://datasheet.ciiva.com/2525/dcrcwe3-2525639.pdf?src-supplier=Digi-Key; ComponentLink5Description: https://datasheet.ciiva.com/2525/dcrcwe3-2525639.pdf?src-supplier=Digi-Key; ComponentLink4URL: https://datasheet.ciiva.com/2525/dcrcwe3-2525638.pdf?src-supplier=Digi-Key; ComponentLink4Description: https://datasheet.ciiva.com/2525/dcrcwe3-2525638.pdf?src-supplier=Digi-Key; ComponentLink3URL: https://datasheet.ciiva.com/11810/0900766b80d98824-11810045.pdf?src-supplier=RSComponents; ComponentLink3Description: https://datasheet.ciiva.com/11810/0900766b80d98824-11810045.pdf?src-supplier=RSComponents; ComponentLink2URL: http://documents.rs-components.com/techlib://itc_doclist_GB.htm?stockno=5049022&src-supplier=RSComponents; ComponentLink2Description: http://documents.rs-components.com/techlib://itc_doclist_GB.htm?stockno=5049022&src-supplier=RSComponents; ComponentLink1URL: http://www.yageo.com/documents/recent/PYu-RC_Group_51_RoHS_L_10.pdf?src-supplier=Digi-Key; ComponentLink1Description: http://www.yageo.com/documents/recent/PYu-RC_Group_51_RoHS_L_10.pdf?src-supplier=Digi-Key; Component Kind: Standard; Case Code (Metric): 1005; Case Code (Imperial): 0402; Case/Package: 0402
R79 = RES_SMD: 017_Manufacturer-1 PN 1: CRCW040232K4FKED; 016_Manufacturer-1 1: Vishay; Voltage Rating: 50V; Tolerance: 1%; Temperature Coefficient: 100ppm/°C; Power Rating: 63mW; Width: 0.5mm; Weight: 2.3E-05oz; Termination: SMD/SMT; Supplier Part Number 1: CRCW040232K4FKED; Supplier 1: Avnet; Stock 1: 8622; RoHS: Yes; REACH SVHC: Unknown; Radiation Hardening: No; Pricing 1: 1=0.08571, 157=0.08333, 313=0.08108, 625=0.07895, 1250=0.07692 (USD); Packaging: Tape and Reel; Package Quantity: 10; Number of Terminations: 2; Number of Pins: 2; Min Operating Temperature: -55°C; Military Standard: Not; Max Power Dissipation: 63mW; Max Operating Temperature: 155°C; Library Name: ElectricMeter.SchLib; Length: 1mm; Height: 0.35mm; Features: Automotive AEC-Q200; Depth: 0.5mm; Contact Plating: Tin; Composition: Thick Film; ComponentLink22URL: https://datasheet.ciiva.com/7671/1697337-7671581.pdf?src-supplier=Element14; ComponentLink22Description: https://datasheet.ciiva.com/7671/1697337-7671581.pdf?src-supplier=Element14; ComponentLink21URL: https://datasheet.ciiva.com/7671/125364-7671771.pdf?src-supplier=Element14; ComponentLink21Description: https://datasheet.ciiva.com/7671/125364-7671771.pdf?src-supplier=Element14; ComponentLink20URL: https://datasheet.ciiva.com/2525/dcrcwe3-2525635.pdf?src-supplier=Digi-Key; ComponentLink20Description: https://datasheet.ciiva.com/2525/dcrcwe3-2525635.pdf?src-supplier=Digi-Key; ComponentLink19URL: https://datasheet.ciiva.com/22668/1533075-22668811.pdf?src-supplier=Element14; ComponentLink19Description: https://datasheet.ciiva.com/22668/1533075-22668811.pdf?src-supplier=Element14; ComponentLink18URL: https://datasheet.ciiva.com/16886/dcrcwe3-16886315.pdf?src-supplier=Avnet+Europe; ComponentLink18Description: https://datasheet.ciiva.com/16886/dcrcwe3-16886315.pdf?src-supplier=Avnet+Europe; ComponentLink17URL: https://datasheet.ciiva.com/22668/1533075-22668810.pdf?src-supplier=Element14; ComponentLink17Description: https://datasheet.ciiva.com/22668/1533075-22668810.pdf?src-supplier=Element14; ComponentLink16URL: https://datasheet.ciiva.com/7743/125364-7743594.pdf?src-supplier=Element14; ComponentLink16Description: https://datasheet.ciiva.com/7743/125364-7743594.pdf?src-supplier=Element14; ComponentLink15URL: https://datasheet.ciiva.com/8336/dcrcwe3-8336728.pdf?src-supplier=Avnet; ComponentLink15Description: https://datasheet.ciiva.com/8336/dcrcwe3-8336728.pdf?src-supplier=Avnet; ComponentLink14URL: https://datasheet.ciiva.com/16761/70201119-16761351.pdf?src-supplier=Allied+Electronics; ComponentLink14Description: https://datasheet.ciiva.com/16761/70201119-16761351.pdf?src-supplier=Allied+Electronics; ComponentLink13URL: https://datasheet.ciiva.com/6013/1533075-6013894.pdf?src-supplier=Farnell; ComponentLink13Description: https://datasheet.ciiva.com/6013/1533075-6013894.pdf?src-supplier=Farnell; ComponentLink12URL: https://datasheet.ciiva.com/22870/70201119-22870901.pdf?src-supplier=Allied+Electronics; ComponentLink12Description: https://datasheet.ciiva.com/22870/70201119-22870901.pdf?src-supplier=Allied+Electronics; ComponentLink11URL: https://datasheet.ciiva.com/6013/1533075-6013893.pdf?src-supplier=Farnell; ComponentLink11Description: https://datasheet.ciiva.com/6013/1533075-6013893.pdf?src-supplier=Farnell; ComponentLink10URL: https://datasheet.ciiva.com/2525/dcrcwe3-2525637.pdf?src-supplier=Digi-Key; ComponentLink10Description: https://datasheet.ciiva.com/2525/dcrcwe3-2525637.pdf?src-supplier=Digi-Key; ComponentLink9URL: https://datasheet.ciiva.com/2525/dcrcwe3-2525636.pdf?src-supplier=Digi-Key; ComponentLink9Description: https://datasheet.ciiva.com/2525/dcrcwe3-2525636.pdf?src-supplier=Digi-Key; ComponentLink8URL: https://datasheet.ciiva.com/11818/0900766b80d98824-11818042.pdf?src-supplier=RSComponents; ComponentLink8Description: https://datasheet.ciiva.com/11818/0900766b80d98824-11818042.pdf?src-supplier=RSComponents; ComponentLink7URL: http://www.farnell.com/datasheets/2045673.pdf?src-supplier=Element14; ComponentLink7Description: http://www.farnell.com/datasheets/2045673.pdf?src-supplier=Element14; ComponentLink6URL: http://www.vishay.com/docs/20035/dcrcwe3.pdf?src-supplier=Avnet+Europe; ComponentLink6Description: http://www.vishay.com/docs/20035/dcrcwe3.pdf?src-supplier=Avnet+Europe; ComponentLink5URL: http://www.farnell.com/datasheets/2111203.pdf?src-supplier=Element14; ComponentLink5Description: http://www.farnell.com/datasheets/2111203.pdf?src-supplier=Element14; ComponentLink4URL: http://www.vishay.com/docs/20035/dcrcwe3.pdf?src-supplier=Avnet; ComponentLink4Description: http://www.vishay.com/docs/20035/dcrcwe3.pdf?src-supplier=Avnet; ComponentLink3URL: https://www.alliedelec.com/m/d/0c934d6939ccefa68dbe0b96d821c8cf.pdf?src-supplier=Allied+Electronics; ComponentLink3Description: https://www.alliedelec.com/m/d/0c934d6939ccefa68dbe0b96d821c8cf.pdf?src-supplier=Allied+Electronics; ComponentLink2URL: http://uk.rs-online.com/webdocs/0d98/0900766b80d98824.pdf?src-supplier=RSComponents; ComponentLink2Description: http://uk.rs-online.com/webdocs/0d98/0900766b80d98824.pdf?src-supplier=RSComponents; ComponentLink1URL: http://www.vishay.com/docs/20035/dcrcwe3.pdf?src-supplier=Digi-Key; ComponentLink1Description: http://www.vishay.com/docs/20035/dcrcwe3.pdf?src-supplier=Digi-Key; Component Kind: Standard; Case Code (Metric): 1005; Case Code (Imperial): 0402; Case/Package: 0402
R80 = RES_SMD: Manufacturer Part Number 1: CRCW040239K2FKED; Manufacturer 1: Vishay; Voltage Rating (DC): 50V; Tolerance: 1%; Temperature Coefficient: 100ppm/°C; Resistance: 39.2kR; Power Rating: 63mW; Width: 0.5mm; Weight: 2.3E-05oz; Voltage Rating: 50V; Termination: SMD/SMT; Supplier Part Number 1: 541-39.2KLCT-ND; Supplier 1: Digi-Key; Stock 1: 47958; RoHS: Yes; REACH SVHC: Unknown; Radiation Hardening: No; Pricing 1: 1=0.10000, 10=0.03800, 100=0.01540, 1000=0.00690, 2500=0.00599, 5000=0.00495 (USD); Packaging: Tape and Reel; Package Quantity: 10; Number of Terminations: 2; Number of Pins: 2; Min Operating Temperature: -55°C; Military Standard: Not; Max Power Dissipation: 63mW; Max Operating Temperature: 155°C; Library Name: ElectricMeter.SchLib; Length: 1mm; Lead Free: Lead Free; Height: 0.35mm; Features: Automotive AEC-Q200; Depth: 0.5mm; Contact Plating: Tin; Composition: Thick Film; ComponentLink13URL: https://datasheet.ciiva.com/7671/1533075-7671772.pdf?src-supplier=Element14; ComponentLink13Description: https://datasheet.ciiva.com/7671/1533075-7671772.pdf?src-supplier=Element14; ComponentLink12URL: https://datasheet.ciiva.com/7671/1697337-7671596.pdf?src-supplier=Element14; ComponentLink12Description: https://datasheet.ciiva.com/7671/1697337-7671596.pdf?src-supplier=Element14; ComponentLink11URL: https://datasheet.ciiva.com/16429/dcrcwe3-16429962.pdf?src-supplier=Future+Electronics; ComponentLink11Description: https://datasheet.ciiva.com/16429/dcrcwe3-16429962.pdf?src-supplier=Future+Electronics; ComponentLink10URL: https://datasheet.ciiva.com/22869/70201119-22869593.pdf?src-supplier=Allied+Electronics; ComponentLink10Description: https://datasheet.ciiva.com/22869/70201119-22869593.pdf?src-supplier=Allied+Electronics; ComponentLink9URL: https://datasheet.ciiva.com/16774/70201119-16774809.pdf?src-supplier=Allied+Electronics; ComponentLink9Description: https://datasheet.ciiva.com/16774/70201119-16774809.pdf?src-supplier=Allied+Electronics; ComponentLink8URL: https://datasheet.ciiva.com/2463/dcrcwe3-2463561.pdf?src-supplier=Digi-Key; ComponentLink8Description: https://datasheet.ciiva.com/2463/dcrcwe3-2463561.pdf?src-supplier=Digi-Key; ComponentLink7URL: https://datasheet.ciiva.com/16882/dcrcwe3-16882152.pdf?src-supplier=Avnet+Europe; ComponentLink7Description: https://datasheet.ciiva.com/16882/dcrcwe3-16882152.pdf?src-supplier=Avnet+Europe; ComponentLink6URL: https://datasheet.ciiva.com/2463/dcrcwe3-2463560.pdf?src-supplier=Digi-Key; ComponentLink6Description: https://datasheet.ciiva.com/2463/dcrcwe3-2463560.pdf?src-supplier=Digi-Key; ComponentLink5URL: https://datasheet.ciiva.com/2463/dcrcwe3-2463559.pdf?src-supplier=Digi-Key; ComponentLink5Description: https://datasheet.ciiva.com/2463/dcrcwe3-2463559.pdf?src-supplier=Digi-Key; ComponentLink4URL: https://datasheet.ciiva.com/3256/dcrcwe3-3256469.pdf?src-supplier=Avnet; ComponentLink4Description: https://datasheet.ciiva.com/3256/dcrcwe3-3256469.pdf?src-supplier=Avnet; ComponentLink3URL: https://datasheet.ciiva.com/11818/0900766b80d98824-11818068.pdf?src-supplier=RSComponents; ComponentLink3Description: https://datasheet.ciiva.com/11818/0900766b80d98824-11818068.pdf?src-supplier=RSComponents; ComponentLink2URL: http://www.yageo.com/documents/recent/PYu-RC_Group_51_RoHS_L_10.pdf?src-supplier=Digi-Key; ComponentLink2Description: http://www.yageo.com/documents/recent/PYu-RC_Group_51_RoHS_L_10.pdf?src-supplier=Digi-Key; ComponentLink1URL: http://www.mouser.com/catalog/specsheets/RC0603.pdf?src-supplier=Mouser; ComponentLink1Description: http://www.mouser.com/catalog/specsheets/RC0603.pdf?src-supplier=Mouser; Component Kind: Standard; Case Code (Metric): 1005; Case Code (Imperial): 0402; Case/Package: 0402
R81 = RES_SMD: 017_Manufacturer-1 PN 1: CRCW04023K24FKED; 016_Manufacturer-1 1: Vishay; Voltage Rating (DC): 50V; Tolerance: 1%; Temperature Coefficient: 100ppm/°C; Power Rating: 63mW; Width: 0.5mm; Weight: 2.3E-05oz; Voltage Rating: 50V; Termination: SMD/SMT; Supplier Part Number 1: CRCW04023K24FKED; Supplier 1: Avnet; Stock 1: 40000; RoHS: Yes; REACH SVHC: Unknown; Radiation Hardening: No; Pricing 1: 10000=0.01136, 20000=0.01105, 40000=0.01075, 60000=0.01047, 100000=0.01020 (USD); Packaging: Tape and Reel; Package Quantity: 10; Number of Terminations: 2; Number of Pins: 2; Mount: Surface Mount; Min Operating Temperature: -55°C; Military Standard: Not; Max Power Dissipation: 63mW; Max Operating Temperature: 155°C; Library Name: ElectricMeter.SchLib; Length: 1mm; Lead Free: Lead Free; Height: 0.35mm; Features: Automotive AEC-Q200; Depth: 0.5mm; Contact Plating: Tin; Composition: Thick Film; ComponentLink11URL: https://datasheet.ciiva.com/16429/dcrcwe3-16429980.pdf?src-supplier=Future+Electronics; ComponentLink11Description: https://datasheet.ciiva.com/16429/dcrcwe3-16429980.pdf?src-supplier=Future+Electronics; ComponentLink10URL: https://datasheet.ciiva.com/2464/dcrcwe3-2464492.pdf?src-supplier=Digi-Key; ComponentLink10Description: https://datasheet.ciiva.com/2464/dcrcwe3-2464492.pdf?src-supplier=Digi-Key; ComponentLink9URL: https://datasheet.ciiva.com/22702/1697337-22702809.pdf?src-supplier=Element14; ComponentLink9Description: https://datasheet.ciiva.com/22702/1697337-22702809.pdf?src-supplier=Element14; ComponentLink8URL: https://datasheet.ciiva.com/7743/125364-7743630.pdf?src-supplier=Element14; ComponentLink8Description: https://datasheet.ciiva.com/7743/125364-7743630.pdf?src-supplier=Element14; ComponentLink7URL: https://datasheet.ciiva.com/2464/dcrcwe3-2464494.pdf?src-supplier=Digi-Key; ComponentLink7Description: https://datasheet.ciiva.com/2464/dcrcwe3-2464494.pdf?src-supplier=Digi-Key; ComponentLink6URL: https://datasheet.ciiva.com/22871/70201119-22871260.pdf?src-supplier=Allied+Electronics; ComponentLink6Description: https://datasheet.ciiva.com/22871/70201119-22871260.pdf?src-supplier=Allied+Electronics; ComponentLink5URL: https://datasheet.ciiva.com/16788/70201119-16788390.pdf?src-supplier=Allied+Electronics; ComponentLink5Description: https://datasheet.ciiva.com/16788/70201119-16788390.pdf?src-supplier=Allied+Electronics; ComponentLink4URL: https://datasheet.ciiva.com/5547/dcrcwe3-109170-5547638.pdf?src-supplier=Mouser; ComponentLink4Description: https://datasheet.ciiva.com/5547/dcrcwe3-109170-5547638.pdf?src-supplier=Mouser; ComponentLink3URL: https://datasheet.ciiva.com/2464/dcrcwe3-2464493.pdf?src-supplier=Digi-Key; ComponentLink3Description: https://datasheet.ciiva.com/2464/dcrcwe3-2464493.pdf?src-supplier=Digi-Key; ComponentLink2URL: http://www.yageo.com.tw/exep/pages/download/literatures/PYu-R_INT-thick_7.pdf?src-supplier=Digi-Key; ComponentLink2Description: http://www.yageo.com.tw/exep/pages/download/literatures/PYu-R_INT-thick_7.pdf?src-supplier=Digi-Key; ComponentLink1URL: http://www.yageo.com/documents/recent/PYu-RC_Group_51_RoHS_L_10.pdf?src-supplier=Digi-Key; ComponentLink1Description: http://www.yageo.com/documents/recent/PYu-RC_Group_51_RoHS_L_10.pdf?src-supplier=Digi-Key; Component Kind: Standard; Case Code (Metric): 1005; Case Code (Imperial): 0402; Case/Package: 0402
R82 = RES_SMD: 017_Manufacturer-1 PN: 0603SAJ0000T5E; 016_Manufacturer-1: Royal Electronic Factory; Tolerance: 1%; 001_Description: Resistor: thick film; SMD; 0603; 0O; 0.1W; ±5%; -55÷125°C; 400ppm/°C; Supplier Part Number 1: 0603SAJ0000T5E; Supplier 1: Verical; Stock 1: 0; Library Name: ElectricMeter.SchLib; Component Kind: Standard; Case/Package: 0603
R87 = RC0603FR-070RL: Chip Resistor - Surface Mount 0Ohms ±1% 1/10W 0603 RoHS; 048_Manufacturer-2 PN: 0603WAJ0000T5E; 047_Manufacturer-2: Uniohm; 016_Manufacturer-1: Yageo; Working Voltage: 75V; Voltage Rating (DC): 50V; Temperature Coefficient: 200ppm/°C; Resistance: 0mR; Power Rating: 100mW; Parameter Name: Tape and Reel; Current Rating: 1A; Width: 0.031inch; Voltage Rating: 50V; Tolerance: 1%; Termination: SMD/SMT; Supplier Part Number 1: RC0603FR-070RL; Supplier 1: Arrow; Stock 1: 60368; REACH SVHC: No SVHC; Radiation Hardening: No; Pricing 1: 5000=0.0019, 1=0.0016, 100=0.0015 (USD); Package Quantity: 5000; Number of Terminations: 2; Number of Pins: 2; Min Operating Temperature: -55°C; Military Standard: Not; Max Power Dissipation: 100mW; Max Operating Temperature: 155°C; Library Name: ElectricMeter.SchLib; Length: 1.6mm; Lead Free: Lead Free; Height: 0.45mm; Features: Moisture Resistant; Depth: 0.8mm; Contact Plating: Tin,Matte; Composition: Thick Film; ComponentLink6URL: http://www.farnell.com/datasheets/1868787.pdf?src-supplier=Element14; ComponentLink6Description: http://www.farnell.com/datasheets/1868787.pdf?src-supplier=Element14; ComponentLink5URL: http://documents.rs-components.com/techlib://itc_doclist_GB.htm?stockno=5048827&src-supplier=RSComponents; ComponentLink5Description: http://documents.rs-components.com/techlib://itc_doclist_GB.htm?stockno=5048827&src-supplier=RSComponents; ComponentLink4URL: http://www.farnell.com/datasheets/443971.pdf?src-supplier=Farnell; ComponentLink4Description: http://www.farnell.com/datasheets/443971.pdf?src-supplier=Farnell; ComponentLink3URL: http://www.yageo.com/documents/recent/PYu-RC_Group_51_RoHS_L_10.pdf?src-supplier=Digi-Key; ComponentLink3Description: http://www.yageo.com/documents/recent/PYu-RC_Group_51_RoHS_L_10.pdf?src-supplier=Digi-Key; ComponentLink2URL: http://www.farnell.com/datasheets/443971.pdf?src-supplier=Element14; ComponentLink2Description: http://www.farnell.com/datasheets/443971.pdf?src-supplier=Element14; ComponentLink1URL: http://www.farnell.com/datasheets/1868787.pdf?src-supplier=Mouser; ComponentLink1Description: http://www.farnell.com/datasheets/1868787.pdf?src-supplier=Mouser; Component Kind: Standard; Case Code (Metric): 1608; Case Code (Imperial): 0603; Case/Package: 0603; 017_Manufacturer-1 PN: RC0603FR-070RL
U11 = TPS54232DR: IC REG BUCK ADJ 2A 8SOIC; 016_Manufacturer-1: Texas Instruments; Synchronous Rectifier: No; Switching Frequency: 1MHz; Quiescent Current: 110uA; Parameter Name: Tape and Reel; Output Voltage: 800mV; Output Type: Adjustable; Output Configuration: Positive; Operating Supply Voltage: 28V; Number of Outputs: 1; Nominal Supply Current: 85uA; Min Supply Voltage: 3.5V; Max Output Voltage: 25V; Weight: 0.002561oz; Supplier Part Number 1: 2781726RL; Supplier 1: Farnell; Stock 1: 0; REACH SVHC: No SVHC; Radiation Hardening: No; Package Quantity: 2500; Output Current: 2A; Number of Pins: 8; Min Output Voltage: 800mV; Min Operating Temperature: -40°C; Min Input Voltage: 3.5V; Max Output Current: 2A; Max Operating Temperature: 150°C; Max Input Voltage: 28V; Library Name: ElectricMeter.SchLib; Lead Free: Lead Free; Contact Plating: Gold; ComponentLink3URL: http://www.ti.com/lit/ds/symlink/tps54232.pdf?src-supplier=Digi-Key; ComponentLink3Description: http://www.ti.com/lit/ds/symlink/tps54232.pdf?src-supplier=Digi-Key; ComponentLink2URL: http://focus.ti.com/lit/ds/symlink/tps54232.pdf?src-supplier=Avnet+Europe; ComponentLink2Description: http://focus.ti.com/lit/ds/symlink/tps54232.pdf?src-supplier=Avnet+Europe; ComponentLink1URL: http://www.ti.com/general/docs/lit/getliterature.tsp?genericPartNumber=TPS54232&&fileType=pdf&src-supplier=Avnet; ComponentLink1Description: http://www.ti.com/general/docs/lit/getliterature.tsp?genericPartNumber=TPS54232&&fileType=pdf&src-supplier=Avnet; Component Kind: Standard; Case/Package: D; 017_Manufacturer-1 PN: TPS54232DR; 001_Description: IC REG BUCK ADJ 2A 8SOIC
U12 = REGULATOR_Belling_BL8062CB3TR50: IC LDO, 16V input, Belling BL8062CB3TR50  --- IC, LDO, 24V Input, 150mA, with Back-Diode, TLV70450DBVR; 048_Manufacturer-2 PN: /TLV70450DBVR; 047_Manufacturer-2: Texas Instruments; 008_Package: SOT23-5/SOT23-3; 007_Status: MP; 006_SMT/THT: SMT; 005_Process: SMD_Mounter; Library Name: ElectricMeter.SchLib; Component Kind: Standard; 017_Manufacturer-1 PN: BL8062CB3TR50; 016_Manufacturer-1: Belling; 003_Remark: -; 001_Designation: Regulator
